# Altium schematic sheet SNES TST Sheet4.SchDoc
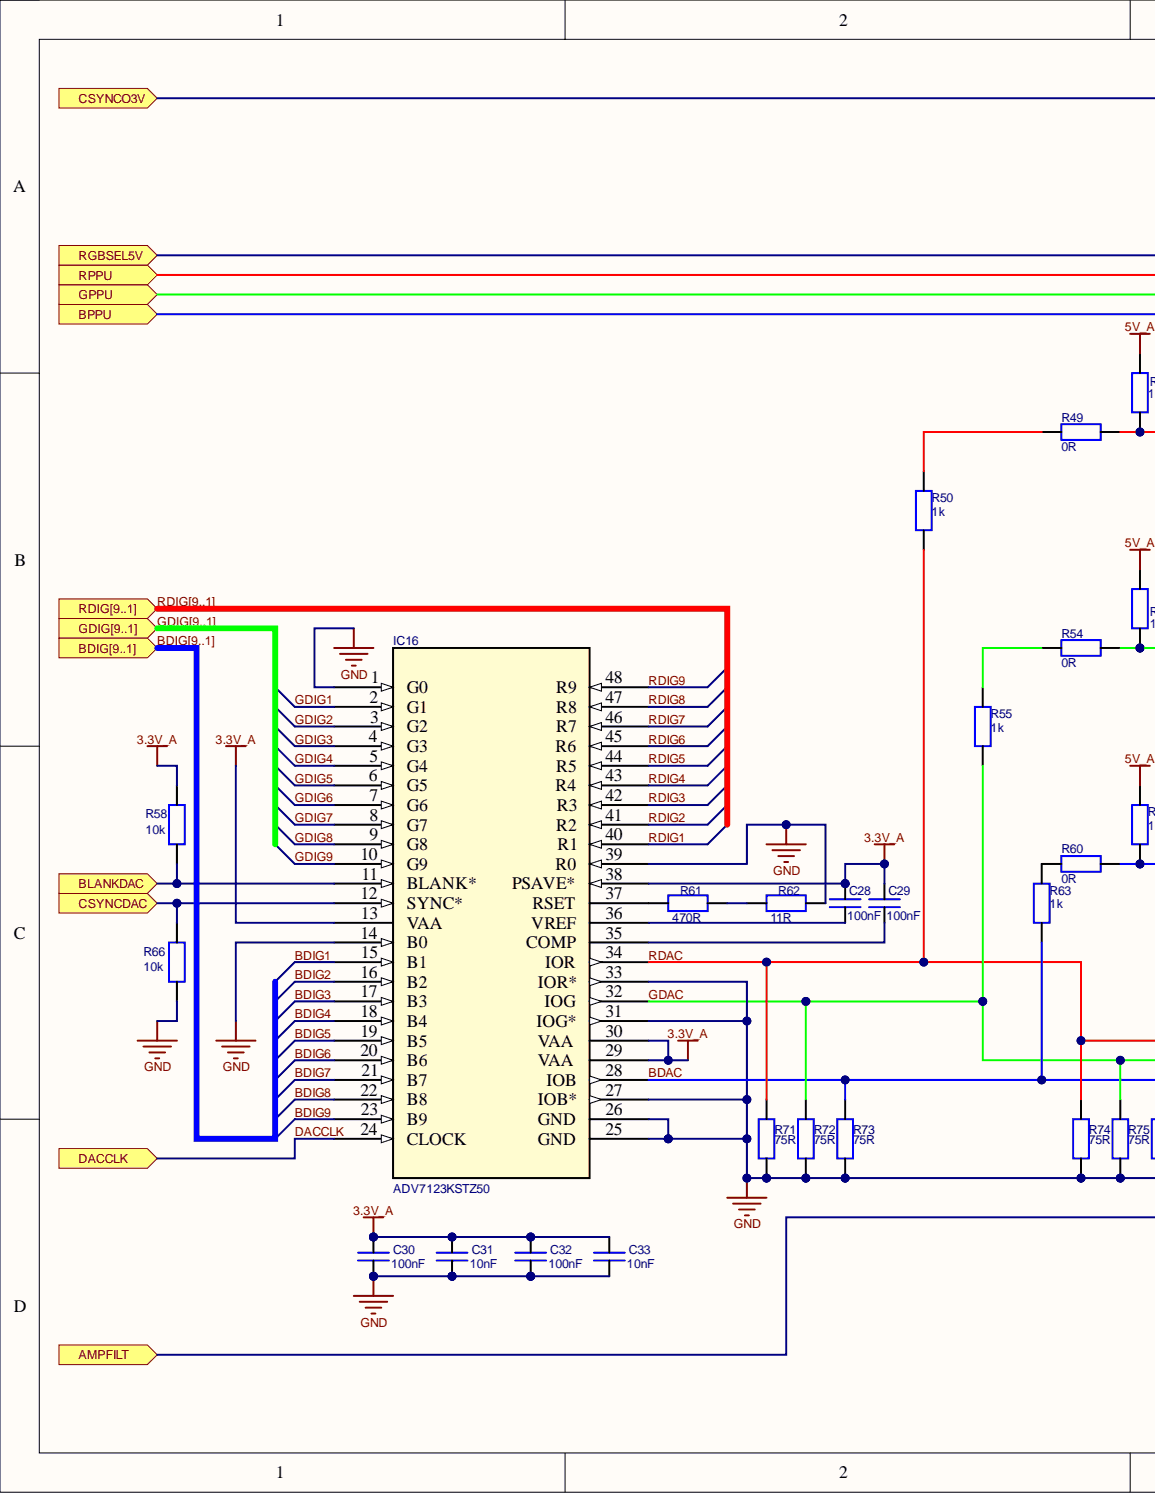
[diagram p0: SNES TST Sheet4.SchDoc, left]
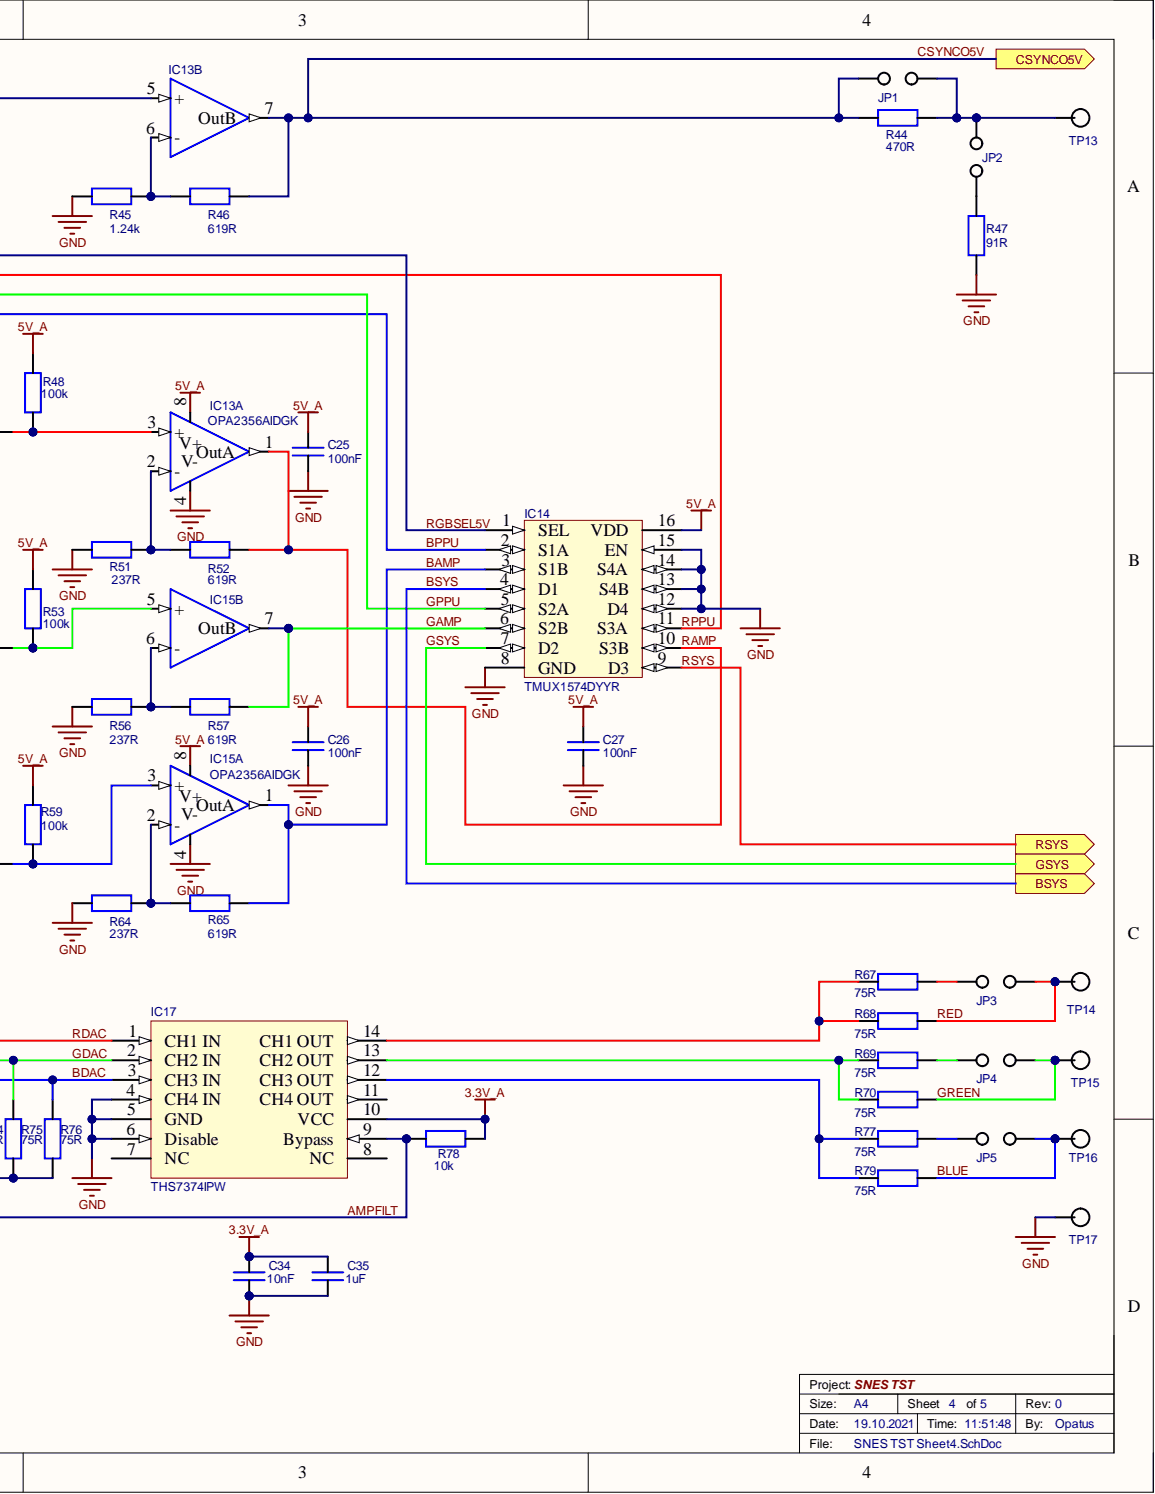
[diagram p1: SNES TST Sheet4.SchDoc, right]

## Parts
C25  100nF  [Cap_0402_Chip]
C26  100nF  [Cap_0402_Chip]
C27  100nF  [Cap_0402_Chip]
C28  100nF  [Cap_0402_Chip]
C29  100nF  [Cap_0402_Chip]
C30  100nF  [Cap_0402_Chip]
C31  10nF  [Cap_0402_Chip]
C32  100nF  [Cap_0402_Chip]
C33  10nF  [Cap_0402_Chip]
C34  10nF  [Cap_0402_Chip]
C35  1uF  [Cap_0603_Chip]
IC13  OPA2356  Manufacturer_Part_Number: OPA2356AIDGK  [MSOP8]  (parts A,B)
IC14  TMUX1574  Manufacturer_Part_Number: TMUX1574DYYR  [SOT-23THIN16]
IC15  OPA2356  Manufacturer_Part_Number: OPA2356AIDGK  [MSOP8]  (parts A,B)
IC16  ADV7123  Manufacturer_Part_Number: ADV7123KSTZ50  [ST_48_ADI]
IC17  THS7374  Manufacturer_Part_Number: THS7374IPW  [TSSOP14]
JP1  Jumper  [Jumper]  (value not drawn)  (no BOM)
JP2  Jumper  [Jumper]  (value not drawn)  (no BOM)
JP3  Jumper  [Jumper]  (value not drawn)  (no BOM)
JP4  Jumper  [Jumper]  (value not drawn)  (no BOM)
JP5  Jumper  [Jumper]  (value not drawn)  (no BOM)
R44  470R  [Res_0603_Chip]
R45  1.24k  [Res_0402_Chip]
R46  619R  [Res_0402_Chip]
R47  91R  [Res_0603_Chip]
R48  100k  [Res_0402_Chip]
R49  0R  [Res_0402_Chip]
R50  1k  [Res_0402_Chip]
R51  237R  [Res_0402_Chip]
R52  619R  [Res_0402_Chip]
R53  100k  [Res_0402_Chip]
R54  0R  [Res_0402_Chip]
R55  1k  [Res_0402_Chip]
R56  237R  [Res_0402_Chip]
R57  619R  [Res_0402_Chip]
R58  10k  [Res_0402_Chip]
R59  100k  [Res_0402_Chip]
R60  0R  [Res_0402_Chip]
R61  470R  [Res_0402_Chip]
R62  11R  [Res_0402_Chip]
R63  1k  [Res_0402_Chip]
R64  237R  [Res_0402_Chip]
R65  619R  [Res_0402_Chip]
R66  10k  [Res_0402_Chip]
R67  75R  [Res_0603_Chip]
R68  75R  [Res_0603_Chip]
R69  75R  [Res_0603_Chip]
R70  75R  [Res_0603_Chip]
R71  75R  [Res_0402_Chip]
R72  75R  [Res_0402_Chip]
R73  75R  [Res_0402_Chip]
R74  75R  [Res_0402_Chip]
R75  75R  [Res_0402_Chip]
R76  75R  [Res_0402_Chip]
R77  75R  [Res_0603_Chip]
R78  10k  [Res_0402_Chip]
R79  75R  [Res_0603_Chip]
TP13  Pin Connector  [Pin Connector Small]  (value not drawn)  (no BOM)
TP14  Pin Connector  [Pin Connector Small]  (value not drawn)  (no BOM)
TP15  Pin Connector  [Pin Connector Small]  (value not drawn)  (no BOM)
TP16  Pin Connector  [Pin Connector Small]  (value not drawn)  (no BOM)
TP17  Pin Connector  [Pin Connector Small]  (value not drawn)  (no BOM)

## Ports
AMPFILT, BDIG1, BDIG2, BDIG3, BDIG4, BDIG5, BDIG6, BDIG7, BDIG8, BDIG9, BDIG[9..1], BLANKDAC, BPPU, BSYS, CSYNCDAC, CSYNCO3V, CSYNCO5V, DACCLK, GDIG1, GDIG2, GDIG3, GDIG4, GDIG5, GDIG6, GDIG7, GDIG8, GDIG9, GDIG[9..1], GPPU, GSYS, RDIG1, RDIG2, RDIG3, RDIG4, RDIG5, RDIG6, RDIG7, RDIG8, RDIG9, RDIG[9..1], RGBSEL5V, RPPU, RSYS

## Nets
3.3V_A: C28.1 C29.1 C30.2 C31.2 C32.2 C33.2 C34.2 C35.2 IC16.13(VAA) IC16.29(VAA) IC16.30(VAA) IC16.38(PSAVE*) IC17.10(VCC) R58.2 R78.1
5V_A: C25.1 C26.1 C27.1 IC13.8(V+) IC14.16(VDD) IC15.8(V+) R48.2 R53.2 R59.2
GND: C25.2 C26.2 C27.2 C30.1 C31.1 C32.1 C33.1 C34.1 C35.1 IC13.4(V-) IC14.8(GND) IC14.12(D4) IC14.13(S4B) IC14.14(S4A) IC14.15(EN) IC15.4(V-) IC16.1(G0) IC16.14(B0) IC16.25(GND) IC16.26(GND) IC16.27(IOB*) IC16.31(IOG*) IC16.33(IOR*) IC16.39(R0) IC17.4(CH4_IN) IC17.5(GND) IC17.6(Disable) R45.1 R47.1 R51.1 R56.1 R62.2 R64.2 R66.1 R71.1 R72.1 R73.1 R74.1 R75.1 R76.1 TP17.1
AMPFILT: IC17.9(Bypass) R78.2 ; port AMPFILT
BAMP: IC14.3(S1B) IC15.1(OutA) R65.2
BDAC: IC16.28(IOB) IC17.3(CH3_IN) R63.2 R73.2 R76.2
BDIG1: IC16.15(B1) ; port BDIG[9..1]  [also labelled BDIG]
BDIG2: IC16.16(B2) ; port BDIG[9..1]  [also labelled BDIG]
BDIG3: IC16.17(B3) ; port BDIG[9..1]  [also labelled BDIG]
BDIG4: IC16.18(B4) ; port BDIG[9..1]  [also labelled BDIG]
BDIG5: IC16.19(B5) ; port BDIG[9..1]  [also labelled BDIG]
BDIG6: IC16.20(B6) ; port BDIG[9..1]  [also labelled BDIG]
BDIG7: IC16.21(B7) ; port BDIG[9..1]  [also labelled BDIG]
BDIG8: IC16.22(B8) ; port BDIG[9..1]  [also labelled BDIG]
BDIG9: IC16.23(B9) ; port BDIG[9..1]  [also labelled BDIG]
BLANKDAC: IC16.11(BLANK*) R58.1 ; port BLANKDAC
BLUE: JP5.1 R79.2 TP16.1
BPPU: IC14.2(S1A) ; port BPPU
BSYS: IC14.4(D1) ; port BSYS
CSYNCDAC: IC16.12(SYNC*) R66.2 ; port CSYNCDAC
CSYNCO3V: IC13.5(+) ; port CSYNCO3V
CSYNCO5V: IC13.7(OutB) JP1.2 R44.1 R46.1 ; port CSYNCO5V
DACCLK: IC16.24(CLOCK) ; port DACCLK
GAMP: IC14.6(S2B) IC15.7(OutB) R57.1
GDAC: IC16.32(IOG) IC17.2(CH2_IN) R55.2 R72.2 R75.2
GDIG1: IC16.2(G1) ; port GDIG[9..1]  [also labelled GDIG]
GDIG2: IC16.3(G2) ; port GDIG[9..1]  [also labelled GDIG]
GDIG3: IC16.4(G3) ; port GDIG[9..1]  [also labelled GDIG]
GDIG4: IC16.5(G4) ; port GDIG[9..1]  [also labelled GDIG]
GDIG5: IC16.6(G5) ; port GDIG[9..1]  [also labelled GDIG]
GDIG6: IC16.7(G6) ; port GDIG[9..1]  [also labelled GDIG]
GDIG7: IC16.8(G7) ; port GDIG[9..1]  [also labelled GDIG]
GDIG8: IC16.9(G8) ; port GDIG[9..1]  [also labelled GDIG]
GDIG9: IC16.10(G9) ; port GDIG[9..1]  [also labelled GDIG]
GPPU: IC14.5(S2A) ; port GPPU
GREEN: JP4.1 R70.2 TP15.1
GSYS: IC14.7(D2) ; port GSYS
RAMP: IC13.1(OutA) IC14.10(S3B) R52.2
RDAC: IC16.34(IOR) IC17.1(CH1_IN) R50.2 R71.2 R74.2
RDIG1: IC16.40(R1) ; port RDIG[9..1]  [also labelled RDIG]
RDIG2: IC16.41(R2) ; port RDIG[9..1]  [also labelled RDIG]
RDIG3: IC16.42(R3) ; port RDIG[9..1]  [also labelled RDIG]
RDIG4: IC16.43(R4) ; port RDIG[9..1]  [also labelled RDIG]
RDIG5: IC16.44(R5) ; port RDIG[9..1]  [also labelled RDIG]
RDIG6: IC16.45(R6) ; port RDIG[9..1]  [also labelled RDIG]
RDIG7: IC16.46(R7) ; port RDIG[9..1]  [also labelled RDIG]
RDIG8: IC16.47(R8) ; port RDIG[9..1]  [also labelled RDIG]
RDIG9: IC16.48(R9) ; port RDIG[9..1]  [also labelled RDIG]
RED: JP3.1 R68.2 TP14.1
RGBSEL5V: IC14.1(SEL) ; port RGBSEL5V
RPPU: IC14.11(S3A) ; port RPPU
RSYS: IC14.9(D3) ; port RSYS
NetC28_2: C28.2 IC16.36(VREF)
NetC29_2: C29.2 IC16.35(COMP)
NetIC13_2: IC13.2(-) R51.2 R52.1
NetIC13_3: IC13.3(+) R48.1 R49.1
NetIC13_6: IC13.6(-) R45.2 R46.2
NetIC15_2: IC15.2(-) R64.1 R65.1
NetIC15_3: IC15.3(+) R59.1 R60.1
NetIC15_5: IC15.5(+) R53.1 R54.1
NetIC15_6: IC15.6(-) R56.2 R57.2
NetIC16_37: IC16.37(RSET) R61.1
NetIC17_12: IC17.12(CH3_OUT) R77.1 R79.1
NetIC17_13: IC17.13(CH2_OUT) R69.1 R70.1
NetIC17_14: IC17.14(CH1_OUT) R67.1 R68.1
NetJP1_1: JP1.1 JP2.1 R44.2 TP13.1
NetJP2_2: JP2.2 R47.2
NetJP3_2: JP3.2 R67.2
NetJP4_2: JP4.2 R69.2
NetJP5_2: JP5.2 R77.2
NetR49_2: R49.2 R50.1
NetR54_2: R54.2 R55.1
NetR60_2: R60.2 R63.1
NetR61_2: R61.2 R62.1

Not connected: IC17 7(NC) 8(NC) 11(CH4_OUT)

## Library data of the parts
C25-C35 = Capacitor: Dielectric: X7R; Nominal Voltage: 10V; Tolerance: +-20%; Component Kind: Standard; Library Name: PCB.SchLib
  C25-C30 C32: Value: 100nF
  C31 C33 C34: Value: 10nF
  C35: Value: 1uF
IC13 IC15 = OPA2356: Vendor: Analog Devices; Component Kind: Standard; Library Name: PCB.SchLib
IC14 = TMUX1574: SPDT 4 Ch; Vendor: Texas Instruments; Component Kind: Standard; Library Name: PCB.SchLib
IC16 = ADV7123KSTZ140: 10Bit Video DAC; Built_By: EMA_UL_Team; SourceLibrary: Analog_2012-01-01_8; Vendor: Analog Devices Inc; Component Kind: Standard; Library Name: PCB.SchLib; RefDes: RefDes; Type: DEV; Copyright: Copyright (C) 2018 Accelerated Designs. All rights reserved
IC17 = THS7374: Vendor: Texas Instruments; Component Kind: Standard; Library Name: PCB.SchLib
R44-R48 R50-R53 R55-R59 R61-R79 = R: Tolerance: +-1%; Component Kind: Standard; Library Name: PCB.SchLib
  R44 R61: Value: 470R
  R45: Value: 1.24k
  R46 R52 R57 R65: Value: 619R
  R47: Value: 91R
  R48 R53 R59: Value: 100k
  R50 R55 R63: Value: 1k
  R51 R56 R64: Value: 237R
  R58 R66 R78: Value: 10k
  R62: Value: 11R
  R67-R77 R79: Value: 75R
R49 R54 R60 = R: Component Kind: Standard; Library Name: PCB.SchLib; Value: 0R
JP1-JP5 = Jumper: Library Name: PCB.SchLib
TP13-TP17 = Pin Connector: Library Name: PCB.SchLib
